# Altium schematic sheet MOS_Driver.SchDoc
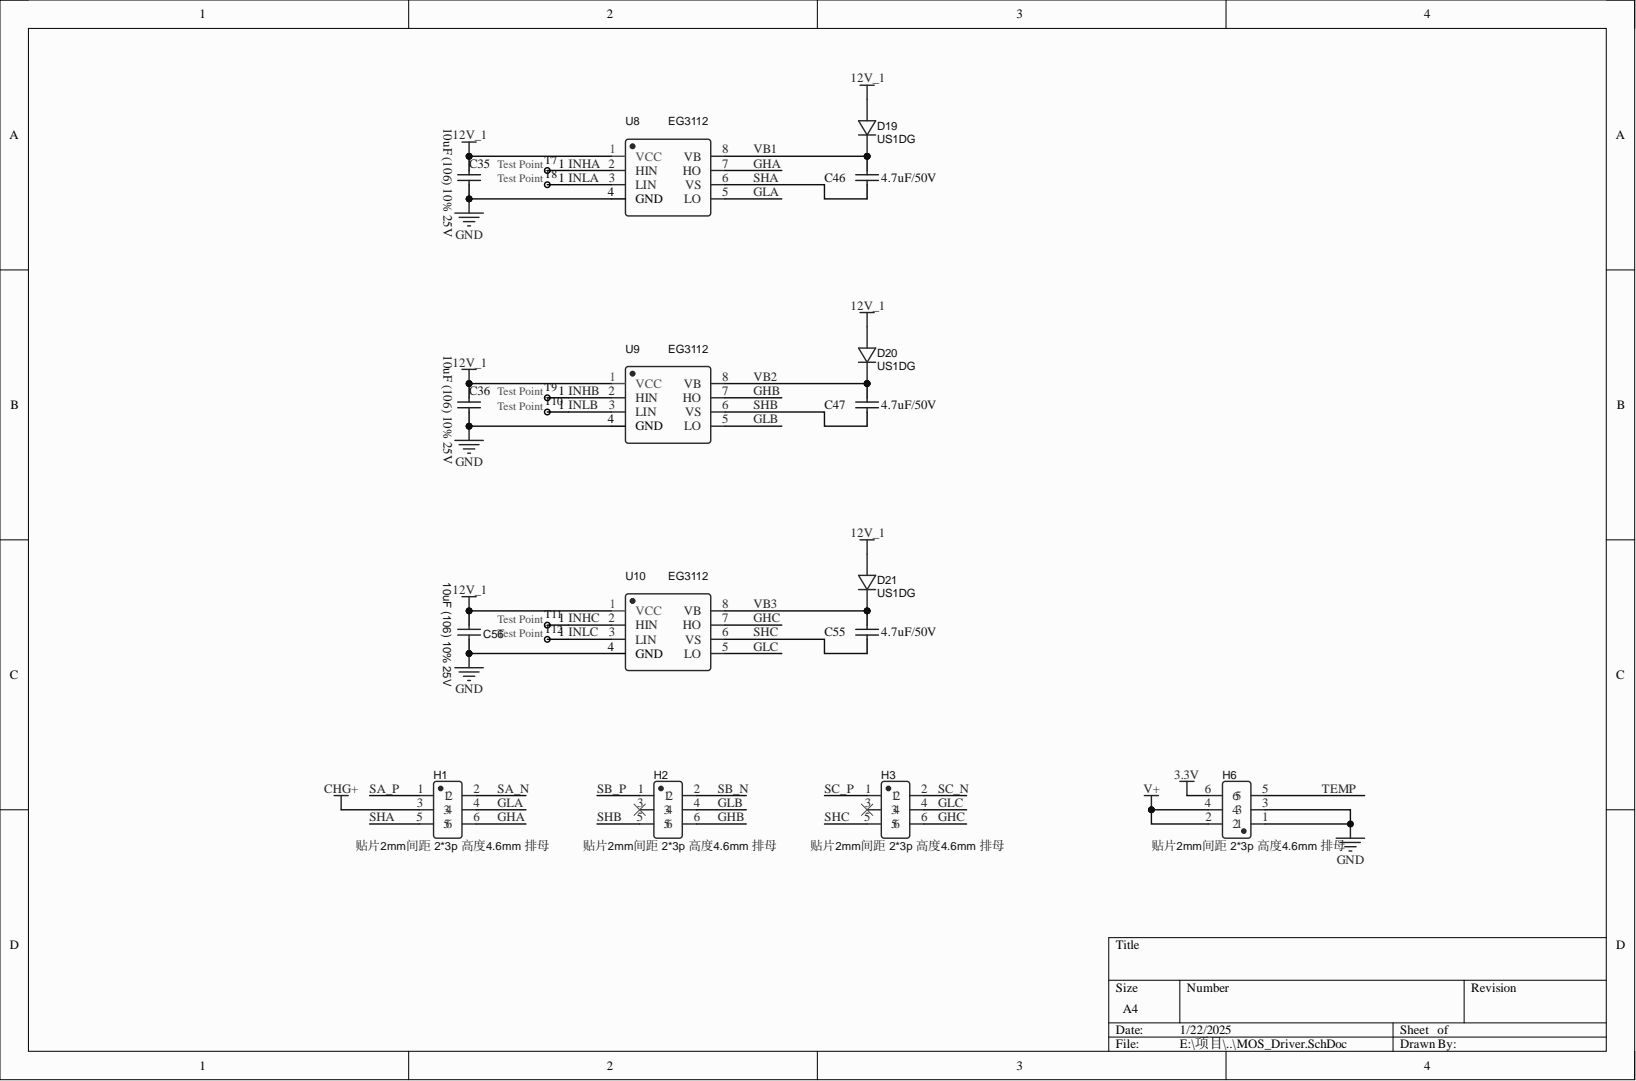
[diagram p0: MOS_Driver.SchDoc]

## Parts
C35  10uF (106) 10% 25V  [0603_C]
C36  10uF (106) 10% 25V  [0603_C]
C46  4.7uF/50V  [1206_C]
C47  4.7uF/50V  [1206_C]
C55  4.7uF/50V  [1206_C]
C56  10uF (106) 10% 25V  [0603_C]
D19  US1DG  [SMA_L4.4-W2.8-LS5.4-RD]
D20  US1DG  [SMA_L4.4-W2.8-LS5.4-RD]
D21  US1DG  [SMA_L4.4-W2.8-LS5.4-RD]
H1  贴片2mm间距 2*3p 高度4.6mm 排母  [HDR-SMD_6P-P2.00-V-F-R2-C3-LS6.5]
H2  贴片2mm间距 2*3p 高度4.6mm 排母  [HDR-SMD_6P-P2.00-V-F-R2-C3-LS6.5]
H3  贴片2mm间距 2*3p 高度4.6mm 排母  [HDR-SMD_6P-P2.00-V-F-R2-C3-LS6.5]
H6  贴片2mm间距 2*3p 高度4.6mm 排母  [HDR-SMD_6P-P2.00-V-F-R2-C3-LS6.5]
T7  Test Point  [TESTPOINT_1MM - small]
T8  Test Point  [TESTPOINT_1MM - small]
T9  Test Point  [TESTPOINT_1MM - small]
T10  Test Point  [TESTPOINT_1MM - small]
T11  Test Point  [TESTPOINT_1MM - small]
T12  Test Point  [TESTPOINT_1MM - small]
U8  EG3112  [SO-8_L4.9-W3.9-P1.27-LS5.9-BL]
U9  EG3112  [SO-8_L4.9-W3.9-P1.27-LS5.9-BL]
U10  EG3112  [SO-8_L4.9-W3.9-P1.27-LS5.9-BL]

## Nets
3.3V: H6.6
12V_1: C35.2 C36.2 C56.1 D19.2 D20.2 D21.2 U8.1(VCC) U9.1(VCC) U10.1(VCC)
CHG+: H1.3
GND: C35.1 C36.1 C56.2 H6.1 H6.3 U8.4(GND) U9.4(GND) U10.4(GND)
V+: H6.2 H6.4
GHA: H1.6 U8.7(HO)
GHB: H2.6 U9.7(HO)
GHC: H3.6 U10.7(HO)
GLA: H1.4 U8.5(LO)
GLB: H2.4 U9.5(LO)
GLC: H3.4 U10.5(LO)
INHA: T7.1 U8.2(HIN)
INHB: T9.1 U9.2(HIN)
INHC: T11.1 U10.2(HIN)
INLA: T8.1 U8.3(LIN)
INLB: T10.1 U9.3(LIN)
INLC: T12.1 U10.3(LIN)
SA_N: H1.2
SA_P: H1.1
SB_N: H2.2
SB_P: H2.1
SC_N: H3.2
SC_P: H3.1
SHA: C46.1 H1.5 U8.6(VS)
SHB: C47.1 H2.5 U9.6(VS)
SHC: C55.1 H3.5 U10.6(VS)
TEMP: H6.5
VB1: C46.2 D19.1 U8.8(VB)
VB2: C47.2 D20.1 U9.8(VB)
VB3: C55.2 D21.1 U10.8(VB)

Not connected: H2 3; H3 3

## Library data of the parts
C35 C36 = 0805 10uF (106) 10% 25V: 贴片电容; Component Kind: Standard; ComponentLink1Description: 供应商链接; ComponentLink1URL: http://www.szlcsc.com/product/details_16532.html; Library Name: Cap.SchLib; Package: 0805; Supplier: LC; SuppliersPartNumber: C15850
C46 C47 C55 = 1206 2.2uF (225) 10% 50V: 贴片电容; Component Kind: Standard; ComponentLink1Description: 供应商链接; ComponentLink1URL: http://www.szlcsc.com/product/details_13292.html; Library Name: Cap.SchLib; Package: 1206; Supplier: LC; SuppliersPartNumber: C12656
C56 = 10uF: BOM_Manufacturer: SAMSUNG; BOM_Manufacturer Part: CL21A106KAYNNNE; nameAlias: Capacitance; SMT Type: Basic; BOM_LCSC Assembly: Yes; BOM_Supplier: LCSC; BOM_Supplier Part: C15850; Component Kind: Standard; spicePre: C; spiceSymbolName: CL21A106KAYNNNE
D19-D21 = US1DG: BOM_Manufacturer: MDD（Microdiode Electronics）; Contributor: LCSC; BOM_JLCPCB Part Class: Extended Part; BOM_Manufacturer Part: US1DG; BOM_Supplier: LCSC; BOM_Supplier Part: C472687; Component Kind: Standard; spicePre: D; spiceSymbolName: US1DG
H1-H3 H6 = X4621FVS-2X03-C43D65: BOM_Manufacturer: XKB Connectivity(中国星坤); Contributor: LCSC; BOM_JLCPCB Part Class: Extended Part; BOM_Manufacturer Part: X4621FVS-2x03-C43D65; BOM_Supplier: LCSC; BOM_Supplier Part: C2684769; Component Kind: Standard; spicePre: H; spiceSymbolName: X4621FVS-2X03-C43D65
T7-T12 = U: Component Kind: Standard
U8-U10 = FD2203: BOM_Manufacturer: Fortior Technol; BOM_JLCPCB Part Class: Extended Part; BOM_Manufacturer Part: FD2203; BOM_Supplier: LCSC; BOM_Supplier Part: C97684; Component Kind: Standard; spicePre: U; spiceSymbolName: FD2203
